# Altium schematic sheet HA_Diagram.SchDoc
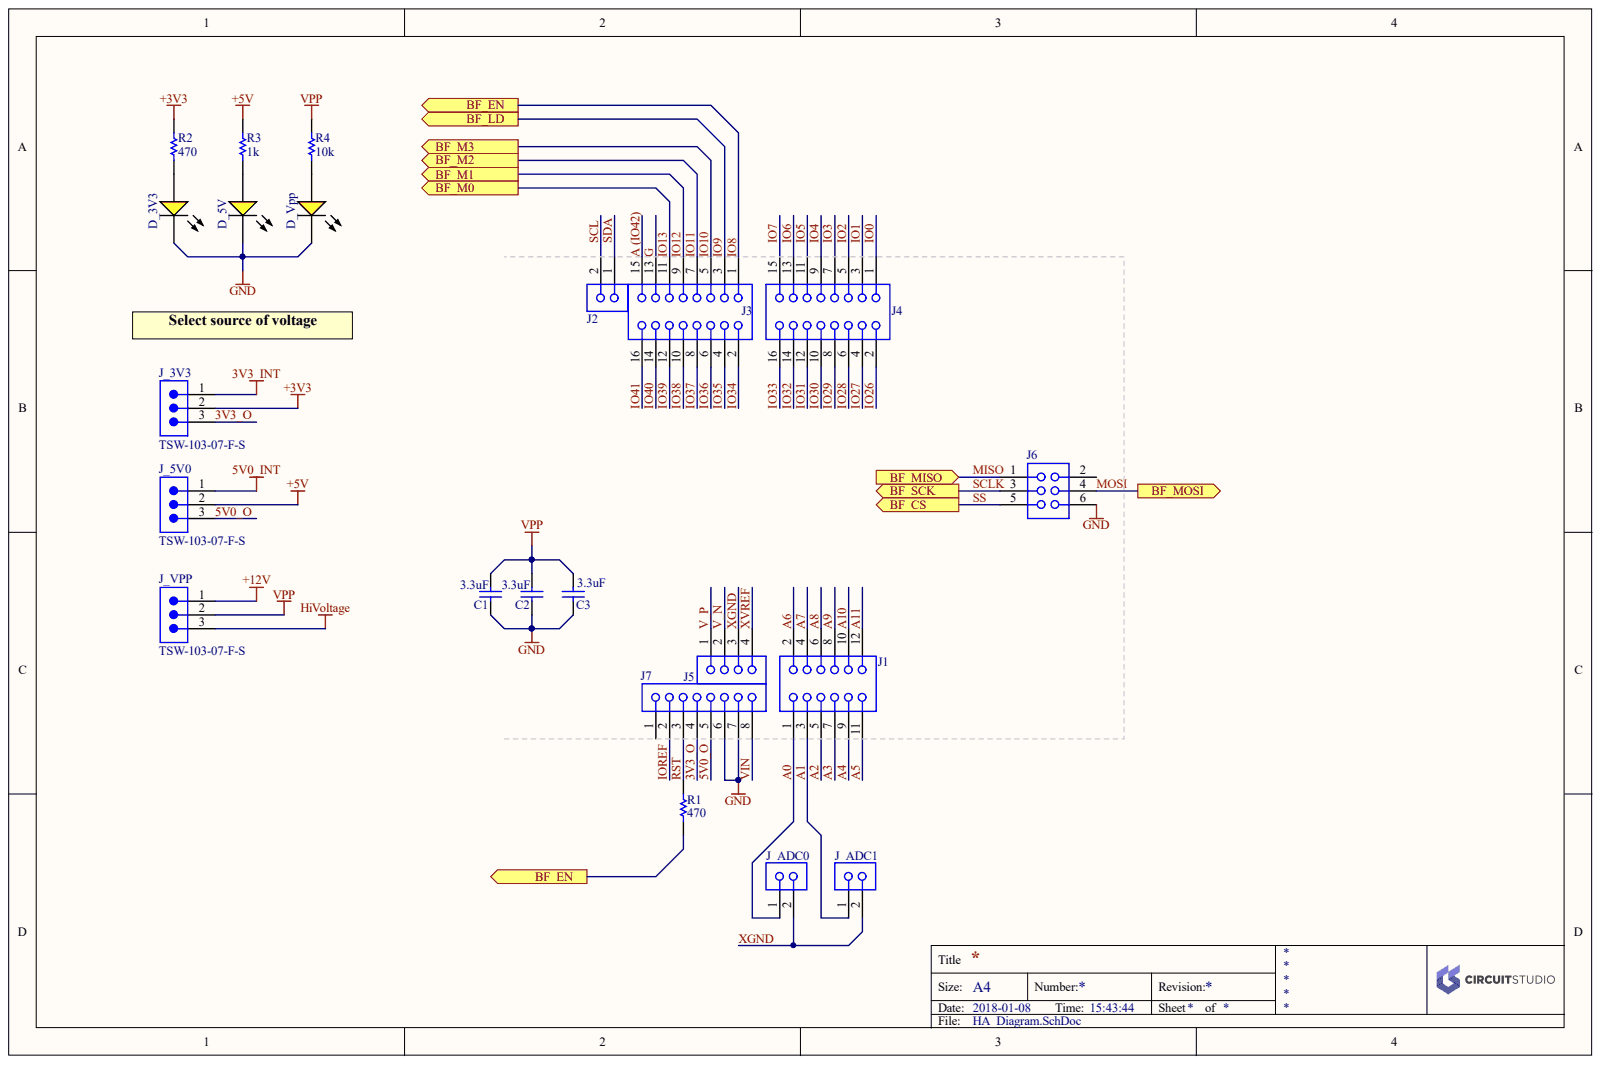
[diagram p0: HA_Diagram.SchDoc]

## Parts
C1  value 3.3uF  [CAPC1206(3216)190_N]  (hidden comment: CAP 3.3uF 100V 1206)
C2  value 3.3uF  [CAPC1206(3216)190_N]  (hidden comment: CAP 3.3uF 100V 1206)
C3  value 3.3uF  [CAPC1206(3216)190_N]  (hidden comment: CAP 3.3uF 100V 1206)
D_3V3  LED 0603  [LTST-C190KSKT_V]  (value not drawn)
D_5V  LED 0603  [LTST-C190KSKT_V]  (value not drawn)
D_Vpp  LED 0603  [LTST-C190KSKT_V]  (value not drawn)
J1  SSW-106-01-F-D  [SMTC-SSW-106-01-X-D]  (value not drawn)
J2  PHF-102-03-L-S  [SMTC-PHF-102-03-X-S]  (value not drawn)
J3  ESW-108-12-G-D  [SMTC-ESW-108-12-X-D]  (value not drawn)
J4  ESW-108-12-G-D  [SMTC-ESW-108-12-X-D]  (value not drawn)
J5  SLW-104-01-F-S  [SMTC-SLW-104-01-X-S]  (value not drawn)
J6  SSW-103-01-F-D  [SMTC-SSW-103-01-X-D]  (value not drawn)
J7  SSQ-108-01-F-S  [SMTC-SSQ-108-01-X-S]  (value not drawn)
J_3V3  TSW-103-07-F-S  [SMTC-TSW-103-07-X-S]
J_5V0  TSW-103-07-F-S  [SMTC-TSW-103-07-X-S]
J_ADC0  PHF-102-03-L-S  [SMTC-PHF-102-03-X-S]  (value not drawn)
J_ADC1  PHF-102-03-L-S  [SMTC-PHF-102-03-X-S]  (value not drawn)
J_VPP  TSW-103-07-F-S  [SMTC-TSW-103-07-X-S]
R1  470  [RESC0603(1608)_N]
R2  470  [RESC0603(1608)_N]
R3  1k  [RESC0603(1608)_N]
R4  10k  [RESC0603(1608)_N]

## Ports
BF_CS, BF_EN, BF_LD, BF_M0, BF_M1, BF_M2, BF_M3, BF_MISO, BF_MOSI, BF_SCK

## Nets
3V3_INT: J_3V3.1
5V0_INT: J_5V0.1
+3V3: J_3V3.2 R2.1
+5V: J_5V0.2 R3.1
+12V: J_VPP.1
GND: C1.1 C2.1 C3.1 D_3V3.2 D_5V.2 D_Vpp.2 J6.6 J7.6 J7.7
HIVOLTAGE: J_VPP.3
VPP: C1.2 C2.2 C3.2 J_VPP.2 R4.1
3V3_O: J7.4 J_3V3.3
5V0_O: J7.5 J_5V0.3
A0: J1.1 J_ADC0.1
A1: J1.3 J_ADC1.1
A2: J1.5
A3: J1.7
A4: J1.9
A5: J1.11
A6: J1.2
A7: J1.4
A8: J1.6
A9: J1.8
A10: J1.10
A11: J1.12
A (IO42): J3.15
BF_CS: J6.5 ; port BF_CS
BF_EN: J3.1 R1.2 ; port BF_EN
BF_LD: J3.3 ; port BF_LD
BF_M0: J3.11 ; port BF_M0
BF_M1: J3.9 ; port BF_M1
BF_M2: J3.7 ; port BF_M2
BF_M3: J3.5 ; port BF_M3
BF_MISO: J6.1 ; port BF_MISO
BF_MOSI: J6.4 ; port BF_MOSI
BF_SCK: J6.3 ; port BF_SCK
G: J3.13
IO0: J4.1
IO1: J4.3
IO2: J4.5
IO3: J4.7
IO4: J4.9
IO5: J4.11
IO6: J4.13
IO7: J4.15
IO26: J4.2
IO27: J4.4
IO28: J4.6
IO29: J4.8
IO30: J4.10
IO31: J4.12
IO32: J4.14
IO33: J4.16
IO34: J3.2
IO35: J3.4
IO36: J3.6
IO37: J3.8
IO38: J3.10
IO39: J3.12
IO40: J3.14
IO41: J3.16
IOREF: J7.2
RST: J7.3 R1.1
SCL: J2.2
SDA: J2.1
V_N: J5.2
V_P: J5.1
VIN: J7.8
XGND: J5.3 J_ADC0.2 J_ADC1.2
XVREF: J5.4
NetD_3V3_1: D_3V3.1 R2.2
NetD_5V_1: D_5V.1 R3.2
NetD_Vpp_1: D_Vpp.1 R4.2

Not connected: J6 2; J7 1

## Library data of the parts
C1-C3 = CMP-1037-04411-1: CAP 3.3uF 100V ±20% 1206 (3216 Metric) Thickness 1.9mm SMD; Case-EIA: 1206; Case-Metric: 3216; Tolerance: Â±20%; Component Kind: Standard; Library Name: Altium Content Vault; Max Thickness: 1.9 mm; Rated Voltage: 100 V; Technology: SMT
D_3V3 D_5V D_Vpp = CMP-1674-00009-1: Case\Package: 0603; Power Rating: 100 mW; Resistance: 12 kohm; Tolerance: 1%; Voltage Rating: 50 V; Case Code (Imperial): 0603; Case Code (Metric): 1608; Component Kind: Standard; ComponentLink1Description: Manufacturer URL; ComponentLink1URL: http://www.us.liteon.com/; ComponentLink2Description: Datasheet; ComponentLink2URL: http://optoelectronics.liteon.com/upload/download/DS-22-99-0189/S_110_LTST-C190KSKT.pdf; DatasheetVersion: Rev. I, 10/2012; Height: 0.45 mm; Length: 1.6 mm; Library Name: Altium Content Vault; Max Operating Temperature: 155 degC; Min Operating Temperature: -55 degC; Mount: Surface Mount; PackageDescription: 2-Pin Surface Mount Device, Body 1.6 x 0.8 mm; Package Quantity: 5000; PackageReference: C190KSKT; Packaging: Tape and Reel; Pins: 2; REACH SVHC: No SVHC; RoHSCompliant: true; Voltage Rating (DC): 50 V; Width: 0.8 mm
J1 = CMP-1023-00357-1: SQ Post Socket, Through-hole, Vertical, -55 to 125 degC, 2.54mm Pitch, 12-Pin, Female, RoHS; Manufacturer: Samtec; Component Kind: Standard; ComponentLink1Description: Manufacturer URL; ComponentLink1URL: http://www.samtec.com; ComponentLink2Description: Datasheet; ComponentLink2URL: http://www.samtec.com/documents/webfiles/pdf/SSW_TH.PDF; ComponentLink3Description: Package Specification; ComponentLink3URL: http://www.samtec.com/documents/webfiles/cpdf/SSW-1XX-XX-XXX-X-XX-XXX-XX-MKT.pdf; DatasheetVersion: F-214; Library Name: Altium Content Vault; Mounting Technology: Through-hole; Orientation: Vertical; PackageDescription: Assembly, Socket Strip .016 X .031 Tail; PackageReference: SSW-106-01-X-D; PackageVersion: CA, 6/1987; PartNumber: SSW-106-01-F-D; Pitch: 2.54 mm; RoHS: True; Temperature Range: -55 to 125 degC
J2 J_ADC0 J_ADC1 = CMP-1023-00105-1: Press Fit Socket, Through-hole, Vertical, -55 to 125 degC, 2.54 mm Pitch, 2-Pin, Female, RoHS; Manufacturer: Samtec; Pitch: 2.54 mm; Component Kind: Standard; ComponentLink1Description: Manufacturer URL; ComponentLink1URL: http://www.samtec.com; ComponentLink2Description: Datasheet; ComponentLink2URL: http://www.samtec.com/documents/webfiles/pdf/phf.pdf; ComponentLink3Description: Package Specification; ComponentLink3URL: http://www.samtec.com/documents/webfiles/cpdf/PHF-1XX-XX-X-X-XXX-MKT.pdf; DatasheetVersion: F-214; Library Name: Altium Content Vault; Mounting Technology: Through-hole; Orientation: Vertical; PackageDescription: .100 Press Fit Socket Assembly; PackageReference: PHF-102-03-X-S; PackageVersion: F, 9/2009; PartNumber: PHF-102-03-L-S; RoHS: True; Temperature Range: -55 to 125 degC
J3 J4 = CMP-1023-00046-1: Elevated Socket, Through-hole, Vertical, -55 to 125 degC, 2.54 mm Pitch, 16-Pin, Female, RoHS; Manufacturer: Samtec; Pitch: 2.54 mm; Component Kind: Standard; ComponentLink1Description: Manufacturer URL; ComponentLink1URL: http://www.samtec.com; ComponentLink2Description: Datasheet; ComponentLink2URL: http://www.samtec.com/documents/webfiles/pdf/ESW_TH.PDF; ComponentLink3Description: Package Specification; ComponentLink3URL: http://www.samtec.com/documents/webfiles/cpdf/ESW-1XX-XX-X-X-XX-XX-MKT.pdf; DatasheetVersion: F-214; Library Name: Altium Content Vault; Mounting Technology: Through-hole; Orientation: Vertical; PackageDescription: ESW Strip ASM; PackageReference: ESW-108-12-X-D; PackageVersion: AV, 7/1985; PartNumber: ESW-108-12-G-D; RoHS: True; Temperature Range: -55 to 125 degC
J5 = CMP-1023-00132-1: Low Profile Socket Strips, Through-hole, Vertical, -55 to 125 degC, 2.54 mm Pitch, 4-Pin, Female, RoHS; Manufacturer: Samtec; Pitch: 2.54 mm; Component Kind: Standard; ComponentLink1Description: Manufacturer URL; ComponentLink1URL: http://www.samtec.com; ComponentLink2Description: Datasheet; ComponentLink2URL: http://www.samtec.com/documents/webfiles/pdf/SLW.PDF; ComponentLink3Description: Package Specification; ComponentLink3URL: http://www.samtec.com/documents/webfiles/cpdf/SLW-1XX-01-X-X-MKT.pdf; DatasheetVersion: F-214; Library Name: Altium Content Vault; Mounting Technology: Through-hole; Orientation: Vertical; PackageDescription: Low Profile Socket Strip; PackageReference: SLW-104-01-X-S; PackageVersion: X, 9/1987; PartNumber: SLW-104-01-F-S; RoHS: True; Temperature Range: -55 to 125 degC
J6 = CMP-1023-00329-1: SQ Post Socket, Through-hole, Vertical, -55 to 125 degC, 2.54 mm Pitch, 6-Pin, Female, RoHS; Manufacturer: Samtec; Pitch: 2.54 mm; Component Kind: Standard; ComponentLink1Description: Manufacturer URL; ComponentLink1URL: http://www.samtec.com; ComponentLink2Description: Datasheet; ComponentLink2URL: http://www.samtec.com/documents/webfiles/pdf/SSW_TH.PDF; ComponentLink3Description: Package Specification; ComponentLink3URL: http://www.samtec.com/documents/webfiles/cpdf/SSW-1XX-XX-XXX-X-XX-XXX-XX-MKT.pdf; DatasheetVersion: F-214; Library Name: Altium Content Vault; Mounting Technology: Through-hole; Orientation: Vertical; PackageDescription: Assembly, Socket Strip .016 X .031 Tail; PackageReference: SSW-103-01-X-D; PackageVersion: CA, 6/1987; PartNumber: SSW-103-01-F-D; RoHS: True; Temperature Range: -55 to 125 degC
J7 = CMP-1023-00294-1: SQ Post Socket, Through-hole, Vertical, -55 to 125 degC, 2.54 mm Pitch, 8-Pin, Female, RoHS; Manufacturer: Samtec; Pitch: 2.54 mm; Component Kind: Standard; ComponentLink1Description: Manufacturer URL; ComponentLink1URL: http://www.samtec.com; ComponentLink2Description: Datasheet; ComponentLink2URL: http://www.samtec.com/documents/webfiles/pdf/SSQ_TH.PDF; ComponentLink3Description: Package Specification; ComponentLink3URL: http://www.samtec.com/documents/webfiles/cpdf/SSQ-1XX-XX-XXX-X-XX-XXX-XX-X-MKT.pdf; DatasheetVersion: F-214; Library Name: Altium Content Vault; Mounting Technology: Through-hole; Orientation: Vertical; PackageDescription: Assembly, Socket Strip .025[0.64] SQ Tail; PackageReference: SSQ-108-01-X-S; PackageVersion: BD, 6/1987; PartNumber: SSQ-108-01-F-S; RoHS: True; Temperature Range: -55 to 125 degC
J_3V3 J_5V0 J_VPP = CMP-1024-00331-1: 0.025" SQ Post Header, Through-hole, Vertical, -55 to 125 degC, 2.54 mm Pitch, 3-Pin, Male, RoHS; Manufacturer: Samtec; Pitch: 2.54 mm; Component Kind: Standard; ComponentLink1Description: Manufacturer URL; ComponentLink1URL: http://www.samtec.com; ComponentLink2Description: Datasheet; ComponentLink2URL: http://www.samtec.com/documents/webfiles/pdf/TSW_TH.PDF; ComponentLink3Description: Package Specification; ComponentLink3URL: http://www.samtec.com/documents/webfiles/cpdf/TSW-XXX-XX-XXX-X-XX-XXX-MKT.pdf; DatasheetVersion: F-214; Library Name: Altium Content Vault; Mounting Technology: Through-hole; Orientation: Vertical; PackageDescription: .025[0.64] SQ. Post Terminal Strip Assembly; PackageReference: TSW-103-07-X-S; PackageVersion: DM, 8/1988; PartNumber: TSW-103-07-F-S; RoHS: True; Temperature Range: -55 to 125 degC
R1-R4 = CMP-1012-00122-1: 200 1% 0603 SMD; Value: 200 Ohm; Component Kind: Standard; Library Name: Altium Content Vault; Tolerance: 1 %
